# Altium schematic sheet 06_PWM_IO.SchDoc(06_PWM_IO)
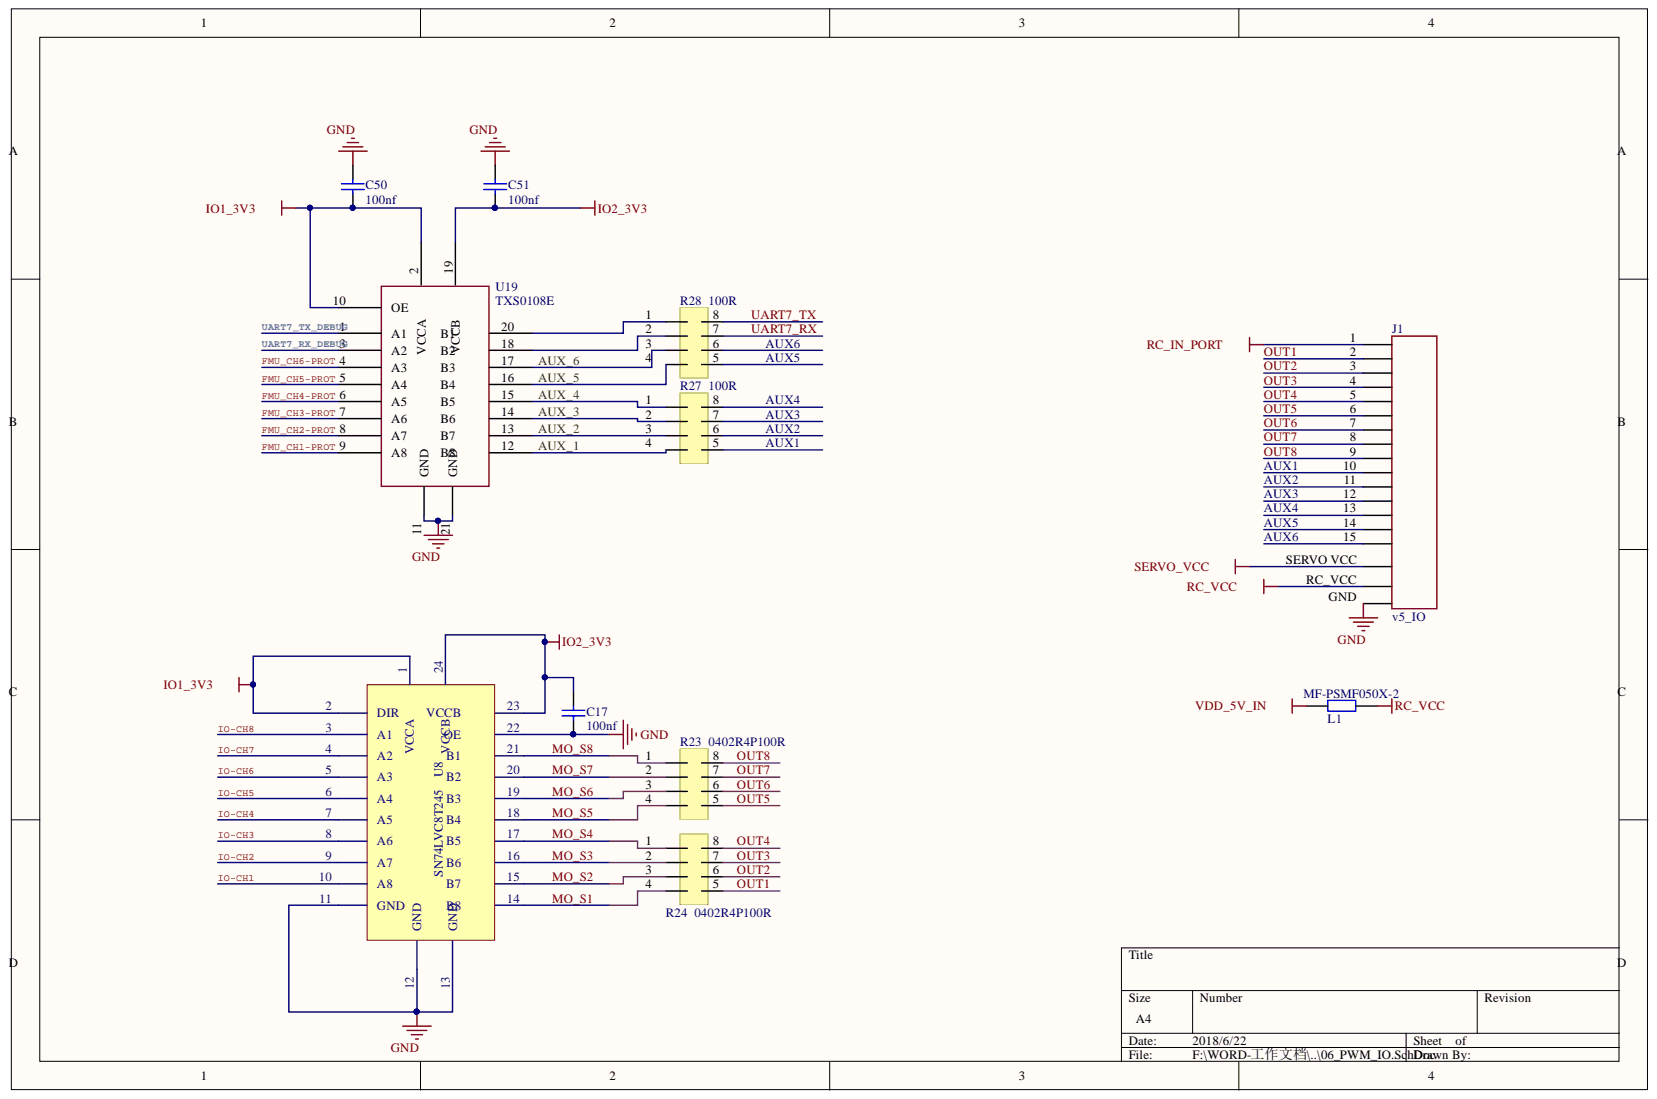
[diagram p0: 06_PWM_IO.SchDoc]

## Parts
C17  100nf  [0402CAP]
C50  100nf  [0402CAP]
C51  100nf  [0402CAP]
J1  v5_IO  [V5_IO_PWM]
L1  MF-PSMF050X-2  [0805l]
R23  0402R4P100R  [0402_4r]
R24  0402R4P100R  [0402_4r]
R27  100R  [0402_4r]
R28  100R  [0402_4r]
U8  SN74LVC8T245  [QFN24-RGY]
U19  TXS0108E  [VQFN20]

## Nets
GND: C17.1 C50.1 C51.1 J1.GND U8.11(GND) U8.12(GND) U8.13(GND) U8.22 U19.11(GND) U19.21(GND)
IO1_3V3: C50.2 U8.1 U8.2(DIR) U19.2 U19.10(OE)
IO2_3V3: C17.2 C51.2 U8.23 U8.24 U19.19
RC_IN_PORT: J1.1
RC_VCC: J1.RC_VCC L1.1
SERVO_VCC: J1.SERVO VCC
VDD_5V_IN: L1.2
AUX1: J1.10 R27.5
AUX2: J1.11 R27.6
AUX3: J1.12 R27.7
AUX4: J1.13 R27.8
AUX5: J1.14 R28.5
AUX6: J1.15 R28.6
AUX_1: R27.4 U19.12
AUX_2: R27.3 U19.13
AUX_3: R27.2 U19.14
AUX_4: R27.1 U19.15
AUX_5: R28.4 U19.16
AUX_6: R28.3 U19.17
FMU_CH1-PROT: U19.9(A8)
FMU_CH2-PROT: U19.8(A7)
FMU_CH3-PROT: U19.7(A6)
FMU_CH4-PROT: U19.6(A5)
FMU_CH5-PROT: U19.5(A4)
FMU_CH6-PROT: U19.4(A3)
IO-CH1: U8.10(A8)
IO-CH2: U8.9(A7)
IO-CH3: U8.8(A6)
IO-CH4: U8.7(A5)
IO-CH5: U8.6(A4)
IO-CH6: U8.5(A3)
IO-CH7: U8.4(A2)
IO-CH8: U8.3(A1)
MO_S1: R24.4 U8.14
MO_S2: R24.3 U8.15
MO_S3: R24.2 U8.16
MO_S4: R24.1 U8.17
MO_S5: R23.4 U8.18
MO_S6: R23.3 U8.19
MO_S7: R23.2 U8.20
MO_S8: R23.1 U8.21
OUT1: J1.2 R24.5
OUT2: J1.3 R24.6
OUT3: J1.4 R24.7
OUT4: J1.5 R24.8
OUT5: J1.6 R23.5
OUT6: J1.7 R23.6
OUT7: J1.8 R23.7
OUT8: J1.9 R23.8
UART7_RX: R28.7
UART7_RX_DEBUG: U19.3(A2)
UART7_TX: R28.8
UART7_TX_DEBUG: U19.1(A1)
NetR28_1: R28.1 U19.20
NetR28_2: R28.2 U19.18

## Library data of the parts
C17 C50 C51 = Cap: 0402 100NF 50V 10%; Component Kind: Standard; Library Name: Miscellaneous Devices.IntLib; Signal Integrity: Cap; Simulation: CAP
L1 = 0402R100R: 0805 500ma 6V; Component Kind: Standard; Library Name: CUAV.SchLib
R23 R24 R27 R28 = 0402-8R-4R: Component Kind: Standard; Library Name: CUAV.SchLib
J1 = v5_IO: Component Kind: Standard; Library Name: CUAV.SchLib
U8 = SN74LVC8T245: Component Kind: Standard; Library Name: CUAV.SchLib
U19 = TXS0108E: Component Kind: Standard; Library Name: CUAV.SchLib
